# Altium schematic sheet ГРЛМ.468731.003 Э3.SchDoc
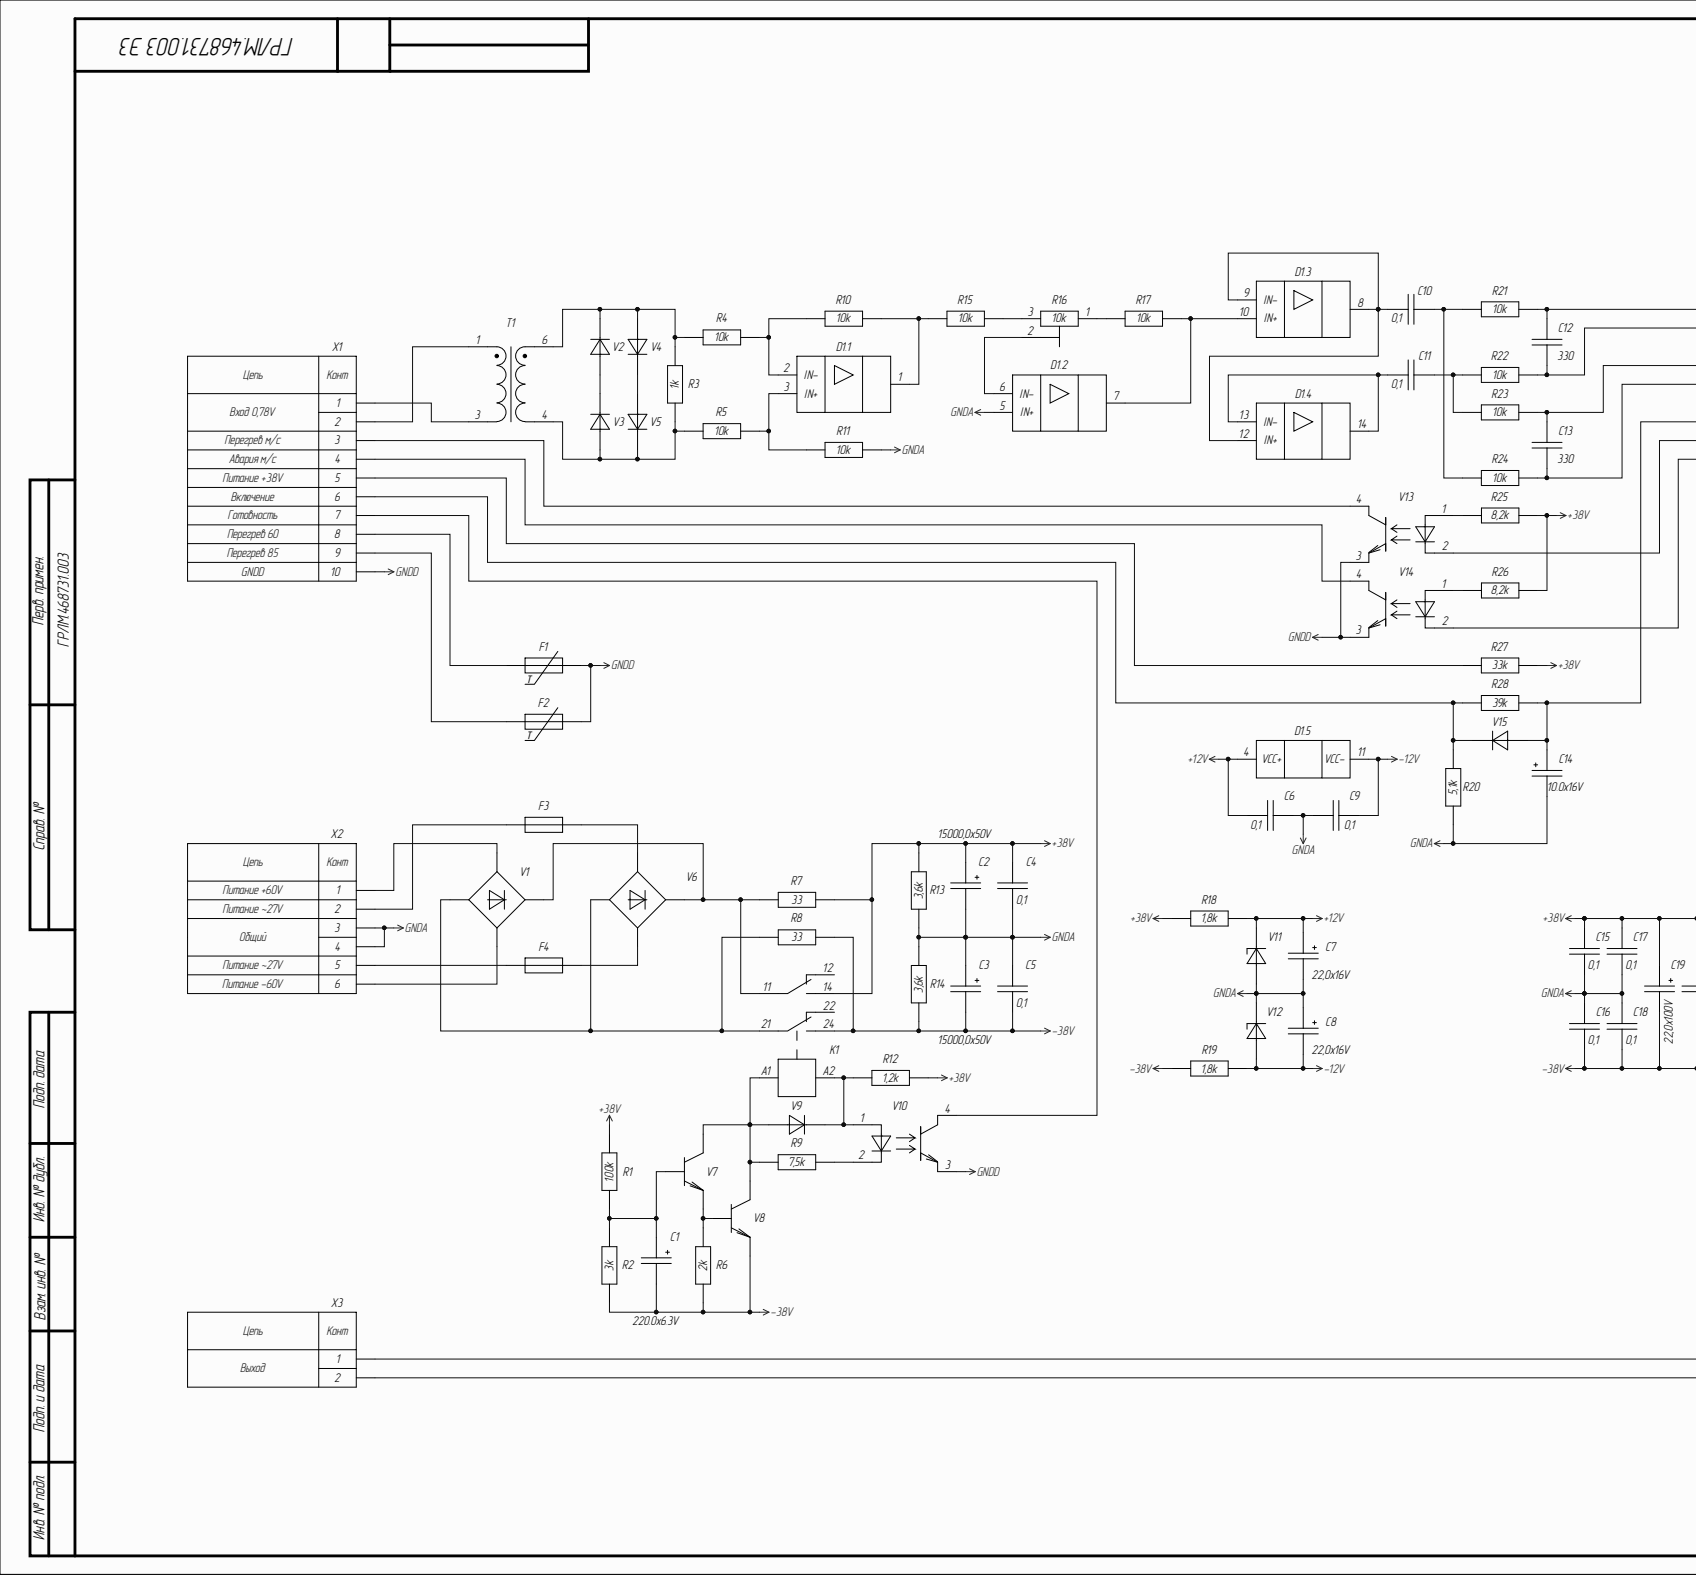
[diagram p0: ГРЛМ.468731.003 Э3.SchDoc, left]
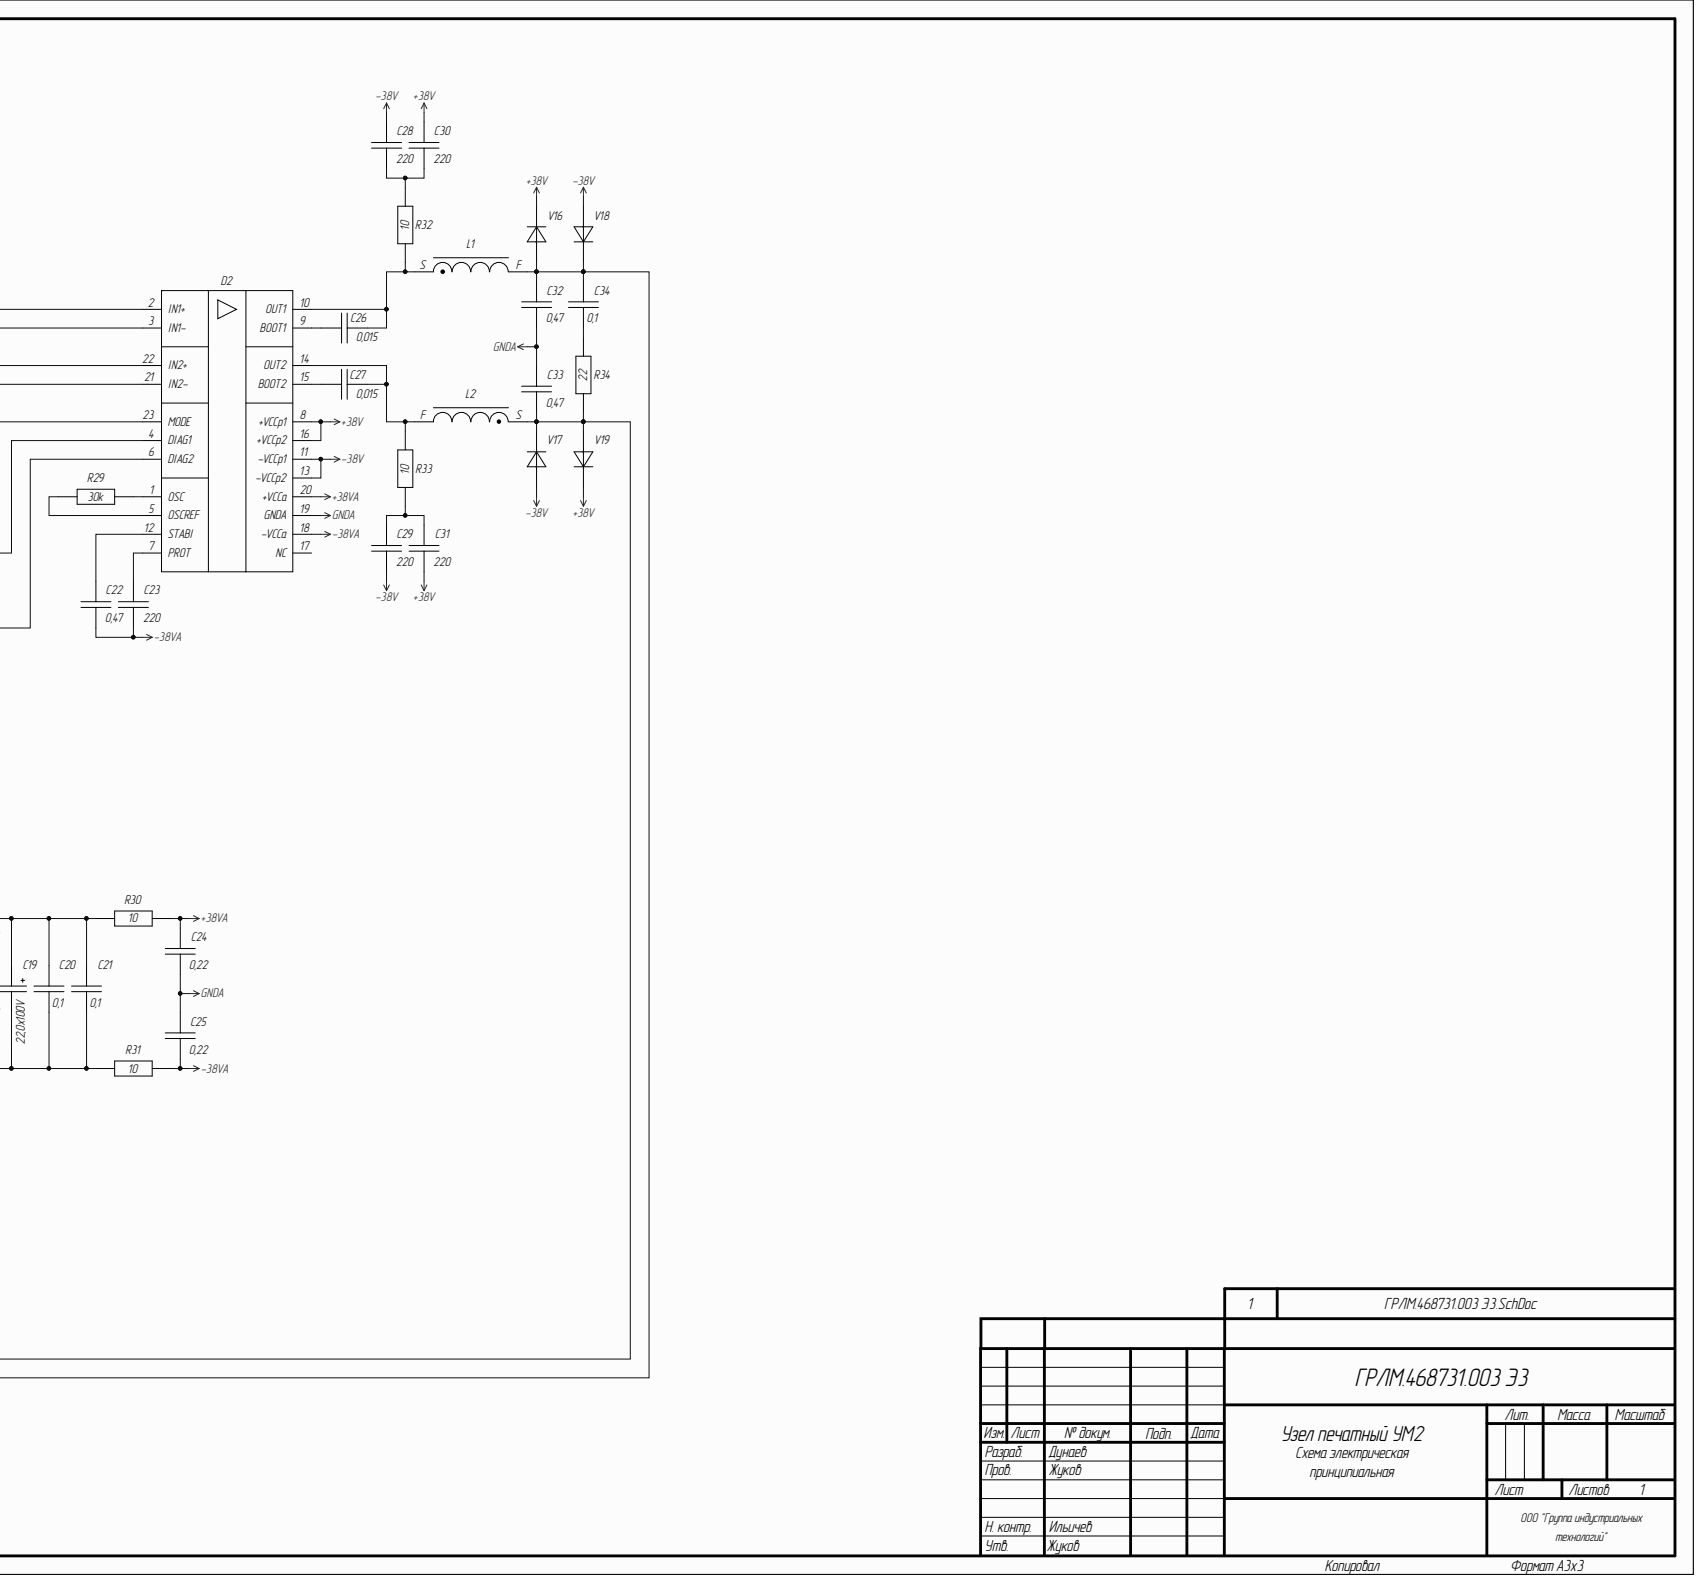
[diagram p1: ГРЛМ.468731.003 Э3.SchDoc, right]

## Parts
C1  value 220.0x6.3V  [CAP-T-C]  (hidden comment: TANCAP_C)
C2  value 15000,0x50V  [B41252A6159M000]  (hidden comment: RADCAP_35x50)
C3  value 15000,0x50V  [B41252A6159M000]  (hidden comment: RADCAP_35x50)
C4  value 0,1  [CAP1206]  (hidden comment: CERCAP_1206)
C5  value 0,1  [CAP1206]  (hidden comment: CERCAP_1206)
C6  value 0,1  [CAP1206]  (hidden comment: CERCAP_1206)
C7  value 22,0x16V  [CAP-T-B]  (hidden comment: TANCAP_B)
C8  value 22,0x16V  [CAP-T-B]  (hidden comment: TANCAP_B)
C9  value 0,1  [CAP1206]  (hidden comment: CERCAP_1206)
C10  value 0,1  [CAP0805]  (hidden comment: CERCAP_0805)
C11  value 0,1  [CAP0805]  (hidden comment: CERCAP_0805)
C12  value 330  [CAP0805]  (hidden comment: CERCAP_0805)
C13  value 330  [CAP0805]  (hidden comment: CERCAP_0805)
C14  value 10.0x16V  [CAP-T-B]  (hidden comment: TANCAP_B)
C15  value 0,1  [CAP0603]  (hidden comment: CERCAP_0603)
C16  value 0,1  [CAP0603]  (hidden comment: CERCAP_0603)
C17  value 0,1  [CAP0603]  (hidden comment: CERCAP_0603)
C18  value 0,1  [CAP0603]  (hidden comment: CERCAP_0603)
C19  value 22,0x100V  [JCK2A220M080105]  (hidden comment: SMDCAP_8x10)
C20  value 0,1  [CAP0603]  (hidden comment: CERCAP_0603)
C21  value 0,1  [CAP0603]  (hidden comment: CERCAP_0603)
C22  value 0,47  [CAP0603]  (hidden comment: CERCAP_0603)
C23  value 220  [CAP0805]  (hidden comment: CERCAP_0805)
C24  value 0,22  [CAP0805]  (hidden comment: CERCAP_0805)
C25  value 0,22  [CAP0805]  (hidden comment: CERCAP_0805)
C26  value 0,015  [CAP0603]  (hidden comment: CERCAP_0603)
C27  value 0,015  [CAP0603]  (hidden comment: CERCAP_0603)
C28  value 220  [CAP0805]  (hidden comment: CERCAP_0805)
C29  value 220  [CAP0805]  (hidden comment: CERCAP_0805)
C30  value 220  [CAP0805]  (hidden comment: CERCAP_0805)
C31  value 220  [CAP0805]  (hidden comment: CERCAP_0805)
C32  value 0,47  [BFC237011474]  (hidden comment: MKT_3.5x8x7.2xP5)
C33  value 0,47  [BFC237011474]  (hidden comment: MKT_3.5x8x7.2xP5)
C34  value 0,1  [CAP1206]  (hidden comment: CERCAP_1206)
D1  TL074IDR  [TL074IDR]  (value not drawn)  (parts .1,.2,.3,.4,.5)
D2  TDA8954J  [TDA8954J]  (value not drawn)
F1  KSDI-60 NO  [KSDI - RADIATOR - ANGLE - RAD]  (value not drawn)
F2  KSDI-85 NO  [KSDI - RADIATOR - ANGLE - RAD - Mirror]  (value not drawn)
F3  Fuse_5x20  [FH-101C]  (value not drawn)
F4  Fuse_5x20  [FH-101C]  (value not drawn)
K1  RT424060  [RT424060]  (value not drawn)
L1  VER2923-223KL  [VER2923]  (value not drawn)
L2  VER2923-223KL  [VER2923]  (value not drawn)
R1  value 100k  [RES1206]  (hidden comment: RES_1206)
R2  value 3k  [RES0805]  (hidden comment: RES_0805)
R3  value 1k  [RES0805]  (hidden comment: RES_0805)
R4  value 10k  [RES0805]  (hidden comment: RES_0805)
R5  value 10k  [RES0805]  (hidden comment: RES_0805)
R6  value 2k  [RES0805]  (hidden comment: RES_0805)
R7  value 33  [SQP-5]  (hidden comment: SQP-5)
R8  value 33  [SQP-5]  (hidden comment: SQP-5)
R9  value 7,5k  [RES2010]  (hidden comment: RES_2010)
R10  value 10k  [RES0805]  (hidden comment: RES_0805)
R11  value 10k  [RES0805]  (hidden comment: RES_0805)
R12  value 1,2k  [RES2010]  (hidden comment: RES_2010)
R13  value 3,6k  [RES2010]  (hidden comment: RES_2010)
R14  value 3,6k  [RES2010]  (hidden comment: RES_2010)
R15  value 10k  [RES0805]  (hidden comment: RES_0805)
R16  value 10k  [3296W]  (hidden comment: 3296W-1)
R17  value 10k  [RES0805]  (hidden comment: RES_0805)
R18  value 1,8k  [RES2010]  (hidden comment: RES_2010)
R19  value 1,8k  [RES2010]  (hidden comment: RES_2010)
R20  value 5,1k  [RES0805]  (hidden comment: RES_0805)
R21  value 10k  [RES0805]  (hidden comment: RES_0805)
R22  value 10k  [RES0805]  (hidden comment: RES_0805)
R23  value 10k  [RES0805]  (hidden comment: RES_0805)
R24  value 10k  [RES0805]  (hidden comment: RES_0805)
R25  value 8,2k  [RES1206]  (hidden comment: RES_1206)
R26  value 8,2k  [RES1206]  (hidden comment: RES_1206)
R27  value 33k  [RES0805]  (hidden comment: RES_0805)
R28  value 39k  [RES0805]  (hidden comment: RES_0805)
R29  value 30k  [RES1206]  (hidden comment: RES_1206)
R30  value 10  [RES0805]  (hidden comment: RES_0805)
R31  value 10  [RES0805]  (hidden comment: RES_0805)
R32  value 10  [RES1206]  (hidden comment: RES_1206)
R33  value 10  [RES1206]  (hidden comment: RES_1206)
R34  value 22  [RES2512]  (hidden comment: RES_2512)
T1  SM-LP-5001  [SM-LP-5001]  (value not drawn)
V1  KBU10M  [KBU10M-7мм - 1mm]  (value not drawn)
V2  LL4148  [LL4148]  (value not drawn)
V3  LL4148  [LL4148]  (value not drawn)
V4  LL4148  [LL4148]  (value not drawn)
V5  LL4148  [LL4148]  (value not drawn)
V6  KBU10M  [KBU10M-7мм - 1mm]  (value not drawn)
V7  MMBT5551LT1G  [MMBT5551LT1G]  (value not drawn)
V8  MMBT5551LT1G  [MMBT5551LT1G]  (value not drawn)
V9  LL4002  [LL4002]  (value not drawn)
V10  HCPL-181-06AE  [HCPL-181]  (value not drawn)
V11  BZX55C12  [BZX55]  (value not drawn)
V12  BZX55C12  [BZX55]  (value not drawn)
V13  HCPL-181-06AE  [HCPL-181]  (value not drawn)
V14  HCPL-181-06AE  [HCPL-181]  (value not drawn)
V15  LL4148  [LL4148]  (value not drawn)
V16  MURS120-E3/52T  [MURS120-E3/52T]  (value not drawn)
V17  MURS120-E3/52T  [MURS120-E3/52T]  (value not drawn)
V18  MURS120-E3/52T  [MURS120-E3/52T]  (value not drawn)
V19  MURS120-E3/52T  [MURS120-E3/52T]  (value not drawn)
X1  SCM-10  [SCM-10]  (value not drawn)
X2  2EDGVC-5.08-06P-14  [2EDGVC-5.08-6]  (value not drawn)
X3  2EDGVC-5.08-02P-14  [2EDGVC-5.08-2]  (value not drawn)

## Nets
+12V: C6.2 C7.1 D1.4(VCC+) R18.1 V11.2
+38V: C2.1 C4.2 C15.1 C17.1 C19.1 C20.1 C21.1 C30.2 C31.1 D2.8(+VCCp1) D2.16(+VCCp2) K1.14 R1.1 R7.2 R12.1 R13.1 R18.2 R25.2 R26.2 R27.1 R30.1 V16.1 V19.1
+38VA: C24.2 D2.20(+VCCa) R30.2
-12V: C8.2 C9.1 D1.11(VCC-) R19.1 V12.1
-38V: C1.2 C3.2 C5.1 C16.2 C18.2 C19.2 C20.2 C21.2 C28.2 C29.1 D2.11(-VCCp1) D2.13(-VCCp2) K1.24 R2.2 R6.2 R8.2 R14.2 R19.2 R31.1 V8.2 V17.2 V18.2
-38VA: C22.2 C23.1 C25.1 D2.18(-VCCa) R31.2
GNDA: C2.2 C3.1 C4.1 C5.2 C6.1 C7.2 C8.1 C9.2 C14.2 C15.2 C16.1 C17.2 C18.1 C24.1 C25.2 C32.1 C33.2 D1.5(IN+) D2.19(GNDA) R11.1 R13.2 R14.1 R20.1 V11.1 V12.2 X2.3 X2.4
GNDD: F1.2 F2.2 V10.3 V13.3 V14.3 X1.10
NetC1_1: C1.1 R1.2 R2.1 V7.1
NetC10_1: C10.1 R21.2 R24.2
NetC10_2: C10.2 D1.8 D1.9(IN-) D1.12(IN+)
NetC11_1: C11.1 R22.2 R23.2
NetC11_2: C11.2 D1.13(IN-) D1.14
NetC12_1: C12.1 D2.2(IN1+) R21.1
NetC12_2: C12.2 D2.3(IN1-) R22.1
NetC13_1: C13.1 D2.22(IN2+) R23.1
NetC13_2: C13.2 D2.21(IN2-) R24.1
NetC14_1: C14.1 D2.23(MODE) R28.1 V15.2
NetC22_1: C22.1 D2.12(STABI)
NetC23_2: C23.2 D2.7(PROT)
NetC26_1: C26.1 D2.9(BOOT1)
NetC26_2: C26.2 D2.10(OUT1) L1.S R32.2
NetC27_1: C27.1 D2.15(BOOT2)
NetC27_2: C27.2 D2.14(OUT2) L2.F R33.1
NetC28_1: C28.1 C30.1 R32.1
NetC29_2: C29.2 C31.2 R33.2
NetC32_2: C32.2 C34.2 L1.F V16.2 V18.1 X3.2
NetC33_1: C33.1 L2.S R34.2 V17.1 V19.2 X3.1
NetC34_1: C34.1 R34.1
NetD1_1: D1.1 R10.1 R15.2
NetD1_2: D1.2(IN-) R4.1 R10.2
NetD1_3: D1.3(IN+) R5.1 R11.2
NetD1_6: D1.6(IN-) R16.2
NetD1_7: D1.7 D1.10(IN+) R17.1
NetD2_1: D2.1(OSC) R29.1
NetD2_4: D2.4(DIAG1) V13.2
NetD2_5: D2.5(OSCREF) R29.2
NetD2_6: D2.6(DIAG2) V14.2
NetF1_1: F1.1 X1.8
NetF2_1: F2.1 X1.9
NetF3_1: F3.1 X2.2
NetF3_2: F3.2 V6.3
NetF4_1: F4.1 X2.5
NetF4_2: F4.2 V6.2
NetK1_11: K1.11 R7.1 V1.4 V6.4
NetK1_21: K1.21 R8.1 V1.1 V6.1
NetK1_A1: K1.A1 R9.2 V7.3 V8.3 V9.2
NetK1_A2: K1.A2 R12.2 V9.1 V10.1
NetR3_1: R3.1 R4.2 T1.6 V2.1 V4.2
NetR3_2: R3.2 R5.2 T1.4 V3.2 V5.1
NetR6_1: R6.1 V7.2 V8.1
NetR9_1: R9.1 V10.2
NetR15_1: R15.1 R16.3
NetR16_1: R16.1 R17.2
NetR20_2: R20.2 R28.2 V15.1 X1.6
NetR25_1: R25.1 V13.1
NetR26_1: R26.1 V14.1
NetR27_2: R27.2 X1.5
NetT1_1: T1.1 X1.2
NetT1_3: T1.3 X1.1
NetV1_2: V1.2 X2.6
NetV1_3: V1.3 X2.1
NetV2_2: V2.2 V3.1
NetV4_1: V4.1 V5.2
NetV10_4: V10.4 X1.7
NetV13_4: V13.4 X1.3
NetV14_4: V14.4 X1.4

Not connected: D2 17(NC); K1 12 22

## Library data of the parts
C1 = TANCAP_C: Конденсатор танталовый; Type: TAJC227K006RNJ; Value: 220.0x6.3V; Артикул: 220 мкФ±10%-6,3В, тип C; Производитель: AVX; Component Kind: Standard; Library Name: Конденсаторы.SchLib
C2 C3 = RADCAP_35x50: Конденсатор электролитический радиальный; Type: B41252A6159M060; Артикул: 15000 мкФ±20%-50В, 35x50, RM10,0; Производитель: Epcos; Component Kind: Standard; Library Name: Конденсаторы.SchLib
C4-C6 C9 C34 = CERCAP_1206: Конденсатор керамический; Type: CC1206KKX7R0BB104; Артикул: 1206-0,1 мкФ±10%-100В-X7R; Производитель: YAGEO; Component Kind: Standard; Library Name: Конденсаторы.SchLib
C7 C8 = TANCAP_B: Конденсатор танталовый; Type: TAJB226K016RNJ; Артикул: 22 мкФ±10%-16В, тип B; Производитель: AVX; Component Kind: Standard; Library Name: Конденсаторы.SchLib
C10 C11 = CERCAP_0805: Конденсатор керамический; Type: CC0805JRX7R9BB104; Артикул: 0805-0,1 мкФ±10%-50В-X7R; Производитель: YAGEO; Component Kind: Standard; Library Name: Конденсаторы.SchLib
C12 C13 = CERCAP_0805: Конденсатор керамический; Type: CC0805JRNPO9BN331; Артикул: 0805-330 пФ±5%-50В-NP0; Производитель: Yageo; Component Kind: Standard; Library Name: Конденсаторы.SchLib
C14 = TANCAP_B: Конденсатор танталовый; Type: TAJB106K016RNJ; Артикул: 10 мкФ±10%-16В, тип B; Производитель: AVX; Component Kind: Standard; Library Name: Конденсаторы.SchLib
C15-C18 = CERCAP_0603: Конденсатор керамический; Type: CC0603KPX7R9BB104; Артикул: 0603-0,1 мкФ±10%-50В-X7R; Производитель: YAGEO; Component Kind: Standard; Library Name: Конденсаторы.SchLib
C19 = SMDCAP_8x10: Конденсатор электролитический SMD; Артикул: 22 мкФ±20%-100В, 8x10; Производитель: JB; Component Kind: Standard; Type: JCK2A220M080105; Library Name: Конденсаторы.SchLib
C20 C21 = CERCAP_0603: Конденсатор керамический; Type: CC0603KRX7R0BB104; Артикул: 0603-0,1 мкФ±10%-100В-X7R; Производитель: YAGEO; Component Kind: Standard; Library Name: Конденсаторы.SchLib
C22 = CERCAP_0603: Конденсатор керамический; Type: CC0603KRX7R9BB474; Артикул: 0603-0,47 мкФ±10%-50В-X7R; Производитель: Yageo; Component Kind: Standard; Library Name: Конденсаторы.SchLib
C23 = CERCAP_0805: Конденсатор керамический; Type: CC0805JRNPO9BN221; Артикул: 0805-220 пФ±5%-50В-NP0; Производитель: Yageo; Component Kind: Standard; Library Name: Конденсаторы.SchLib
C24 C25 = CERCAP_0805: Конденсатор керамический; Type: CC0805KKX7R0BB224; Артикул: 0805-0,22 мкФ±10%-100В-X7R; Производитель: Yageo; Component Kind: Standard; Library Name: Конденсаторы.SchLib
C26 C27 = CERCAP_0603: Конденсатор керамический; Type: CC0603JRX7R9BB153; Артикул: 0603-15 нФ±5%-50В-X7R; Производитель: Yageo; Component Kind: Standard; Library Name: Конденсаторы.SchLib
C28-C31 = CERCAP_0805: Конденсатор керамический; Type: CC0805KRX7R0BB221; Артикул: 0805-220 пФ±10%-100В-X7R; Производитель: Yageo; Component Kind: Standard; Library Name: Конденсаторы.SchLib
C32 C33 = MKT_3.5x8x7.2xP5: Конденсатор пленочный; Артикул: 0,47 мкФ±10%-63В; Производитель: Vishay; Component Kind: Standard; Type: BFC237011474; Library Name: Конденсаторы.SchLib
D1 = TL074IDR: ОУ сдвоенный; Производитель: Texas Instruments; Component Kind: Standard; Library Name: Микросхемы.SchLib
D2 = TDA8954J: Усилитель 300 Вт; Производитель: NXP; Component Kind: Standard; Library Name: Микросхемы.SchLib
F1 = KSDI-60 NO: Терморедохранитель 5А 250В; Component Kind: Standard; Library Name: Прочее.SchLib
F2 = KSDI-85 NO: Терморедохранитель 5А 250В; Component Kind: Standard; Library Name: Прочее.SchLib
F3 F4 = Fuse_5x20: Вставка плавкая 5x20; Type: Siba 179020.8; Производитель: Siba; Component Kind: Standard; Library Name: Прочее.SchLib
K1 = RT424060: Реле DC 60V 8A; Производитель: TE Connectivity; Component Kind: Standard; Library Name: Реле.SchLib
L1 L2 = VER2923-223KL: Дроссель НЧ ызвуковой; Value: 22 мкГн; Артикул: 22 мкГн±10%; Производитель: Coilcraft; Component Kind: Standard; Library Name: Индуктивные элементы.SchLib
R1 = RES_1206: Резистор SMD; Артикул: 0805-100 кОм±5%; Производитель: YAGEO; Component Kind: Standard; Type: RC0805JR-07100KL; Library Name: Резисторы.SchLib
R2 = RES_0805: Резистор SMD; Артикул: 0805-3 кОм±5%; Производитель: YAGEO; Component Kind: Standard; Type: RC0805JR-073KL; Library Name: Резисторы.SchLib
R3 = RES_0805: Резистор SMD; Артикул: 0805-1 кОм±5%; Производитель: YAGEO; Component Kind: Standard; Type: RC0805JR-071KL; Library Name: Резисторы.SchLib
R4 R5 R10 R11 R15 R17 R21-R24 = RES_0805: Резистор SMD; Артикул: 0805-10 кОм±5%; Производитель: YAGEO; Component Kind: Standard; Type: RC0805JR-0710KL; Library Name: Резисторы.SchLib
R6 = RES_0805: Резистор SMD; Артикул: 0805-2 кОм±5%; Производитель: YAGEO; Component Kind: Standard; Type: RC0805JR-072KL; Library Name: Резисторы.SchLib
R7 R8 = SQP-5: Резистор керамический; Артикул: SQP-3-33 Ом±5%; Component Kind: Standard; Library Name: Резисторы.SchLib
R9 = RES_2010: Резистор SMD; Артикул: 2010-7,5 кОм±5%; Производитель: YAGEO; Component Kind: Standard; Type: RC2010JK-077K5L; Library Name: Резисторы.SchLib
R12 = RES_2010: Резистор SMD; Артикул: 2010-1,2 кОм±5%; Производитель: YAGEO; Component Kind: Standard; Type: RC2010JK-071K2L; Library Name: Резисторы.SchLib
R13 R14 = RES_2010: Резистор SMD; Артикул: 2010-3,6 кОм±5%; Производитель: YAGEO; Component Kind: Standard; Type: RC2010JK-073K6L; Library Name: Резисторы.SchLib
R16 = 3296W-1: Резистор подстроечный; Артикул: 10 кОм±10%; Производитель: Bourns; Component Kind: Standard; Type: 3296W-1-103LF; Library Name: Резисторы.SchLib
R18 R19 = RES_2010: Резистор SMD; Артикул: 2010-1,8 кОм±5%; Производитель: YAGEO; Component Kind: Standard; Type: RC2010JR-071K8L; Library Name: Резисторы.SchLib
R20 = RES_0805: Резистор SMD; Артикул: 0805-5,1 кОм±5%; Производитель: YAGEO; Component Kind: Standard; Type: RC0805JR-075K1L; Library Name: Резисторы.SchLib
R25 R26 = RES_1206: Резистор SMD; Артикул: 1206-8,2 кОм±5%; Производитель: YAGEO; Component Kind: Standard; Type: RC1206JR-078K2L; Library Name: Резисторы.SchLib
R27 = RES_0805: Резистор SMD; Артикул: 0805-33 кОм±5%; Производитель: YAGEO; Component Kind: Standard; Type: RC0805JR-0733KL; Library Name: Резисторы.SchLib
R28 = RES_0805: Резистор SMD; Артикул: 0805-39 кОм±5%; Производитель: YAGEO; Component Kind: Standard; Type: RC0805JR-0739KL; Library Name: Резисторы.SchLib
R29 = RES_1206: Резистор SMD; Артикул: 1206-30 кОм±1%; Производитель: YAGEO; Component Kind: Standard; Type: RC1206FR-0730KL; Library Name: Резисторы.SchLib
R30 R31 = RES_0805: Резистор SMD; Артикул: 0805-10 Ом±5%; Производитель: YAGEO; Component Kind: Standard; Type: RC0805JR-0710RL; Library Name: Резисторы.SchLib
R32 R33 = RES_1206: Резистор SMD; Артикул: 1206-10 Ом±5%; Производитель: YAGEO; Component Kind: Standard; Type: RC1206JR-0710RL; Library Name: Резисторы.SchLib
R34 = RES_2512: Резистор SMD; Артикул: 2512-22 Ом±5%; Производитель: YAGEO; Component Kind: Standard; Type: RC2512JR-072RL; Library Name: Резисторы.SchLib
T1 = SM-LP-5001: Трансформатор согласующий; Производитель: Bourns; Component Kind: Standard; Library Name: Индуктивные элементы.SchLib
V1 V6 = KBU10M: Диодный мост 10A; Производитель: NXP; Component Kind: Standard; Library Name: Полупроводники.SchLib
V2-V5 V15 = LL4148: Диод выпрямительный; Производитель: NXP; Component Kind: Standard; Library Name: Полупроводники.SchLib
V7 V8 = MMBT5551LT1G: Транзистор NPN; Производитель: ON Semiconductor; Component Kind: Standard; Library Name: Транзисторы.SchLib
V9 = LL4002: Диод выпрямительный; Производитель: NXP; Component Kind: Standard; Library Name: Полупроводники.SchLib
V10 V13 V14 = HCPL-181-06AE: Оптопара; Производитель: Broadcom; Component Kind: Standard; Library Name: Микросхемы.SchLib
V11 V12 = BZX55C12: Стабилитрон; Производитель: NXP; Component Kind: Standard; Library Name: Полупроводники.SchLib
V16-V19 = MURS120-E3/52T: Диод UltraFast; Производитель: Vishay; Component Kind: Standard; Library Name: Полупроводники.SchLib
X1 = SCM-10: Вилка; Производитель: Connfly; Component Kind: Standard; Library Name: Соединители.SchLib
X2 = 2EDGVC-5.08-06P-14: Вилка; Производитель: Degson; Component Kind: Standard; Library Name: Соединители.SchLib
X3 = 2EDGVC-5.08-02P-14: Вилка; Производитель: Degson; Component Kind: Standard; Library Name: Соединители.SchLib
